# Altium schematic sheet FPGA_SUPPLY.SchDoc(FPGA_SUPPLY)
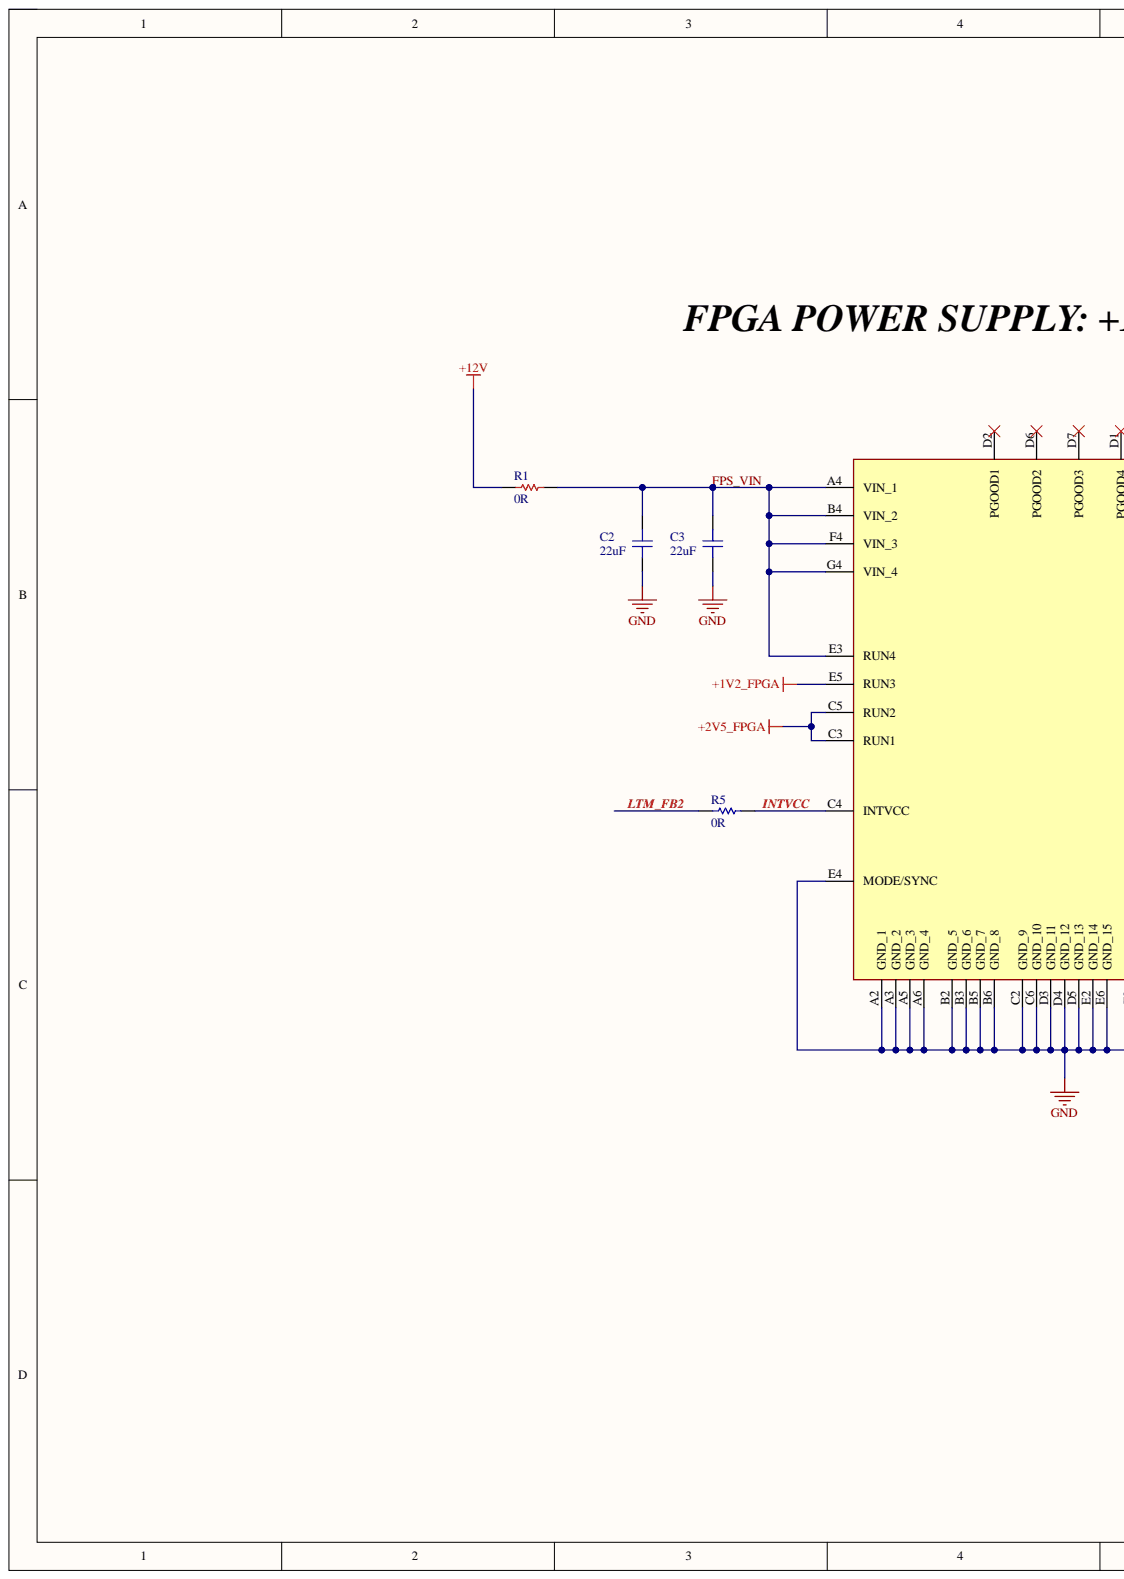
[diagram p0: FPGA_SUPPLY.SchDoc, left]
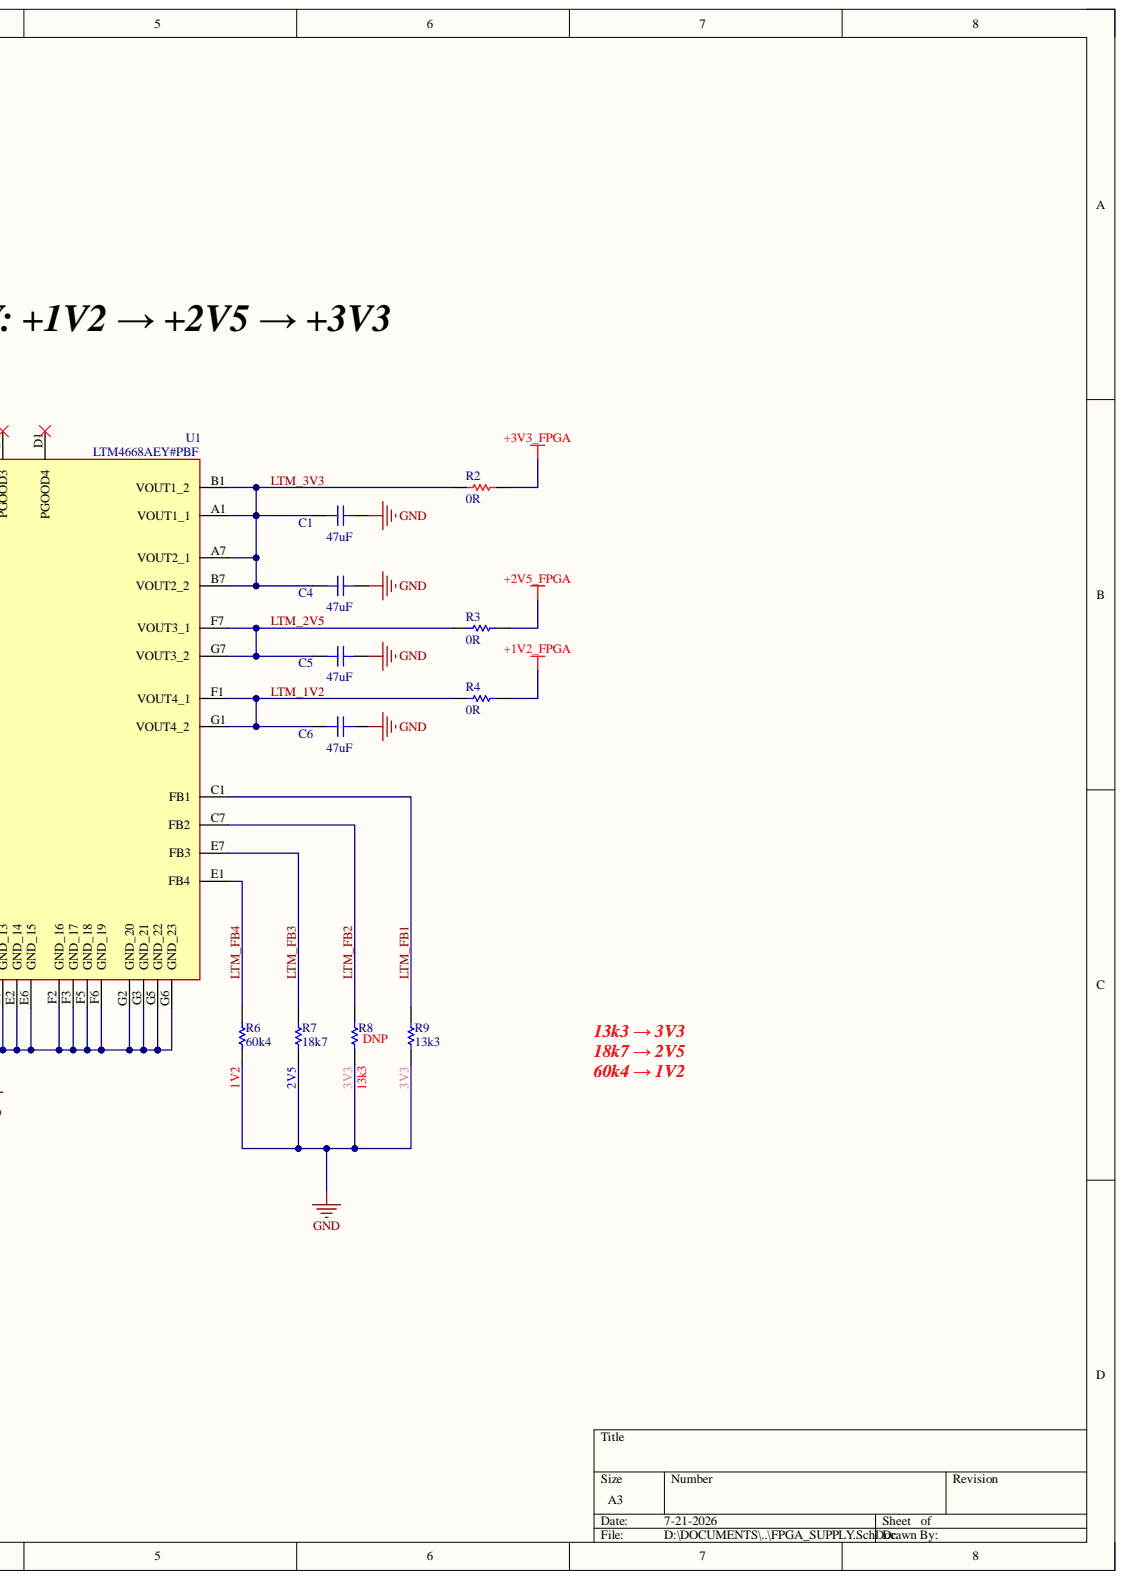
[diagram p1: FPGA_SUPPLY.SchDoc, right]

## Parts
C1  47uF  [CAP_1206]
C2  22uF  [CAP_1206]
C3  22uF  [CAP_1206]
C4  47uF  [CAP_1206]
C5  47uF  [CAP_1206]
C6  47uF  [CAP_1206]
R1  0R  [RES_1206]
R2  0R  [RES_1206]
R3  0R  [RES_0805]
R4  0R  [RES_0805]
R5  0R  [RES_0402]
R6  60k4  [RES_0402]
R7  18k7  [RES_0402]
R8  13k3  [RES_0402]
R9  13k3  [RES_0402]
U1  LTM4668AEY#PBF  [BGA49C80P7X7_625X625X230]

## Nets
+1V2_FPGA: R4.2 U1.E5(RUN3)
+2V5_FPGA: R3.2 U1.C3(RUN1) U1.C5(RUN2)
+3V3_FPGA: R2.2
+12V: R1.1
GND: C1.1 C2.1 C3.1 C4.1 C5.1 C6.1 R6.2 R7.2 R8.2 R9.2 U1.A2(GND_1) U1.A3(GND_2) U1.A5(GND_3) U1.A6(GND_4) U1.B2(GND_5) U1.B3(GND_6) U1.B5(GND_7) U1.B6(GND_8) U1.C2(GND_9) U1.C6(GND_10) U1.D3(GND_11) U1.D4(GND_12) U1.D5(GND_13) U1.E2(GND_14) U1.E4(MODE/SYNC) U1.E6(GND_15) U1.F2(GND_16) U1.F3(GND_17) U1.F5(GND_18) U1.F6(GND_19) U1.G2(GND_20) U1.G3(GND_21) U1.G5(GND_22) U1.G6(GND_23)
FPS_VIN: C2.2 C3.2 R1.2 U1.A4(VIN_1) U1.B4(VIN_2) U1.E3(RUN4) U1.F4(VIN_3) U1.G4(VIN_4)
INTVCC: R5.2 U1.C4(INTVCC)
LTM_1V2: C6.2 R4.1 U1.F1(VOUT4_1) U1.G1(VOUT4_2)
LTM_2V5: C5.2 R3.1 U1.F7(VOUT3_1) U1.G7(VOUT3_2)
LTM_3V3: C1.2 C4.2 R2.1 U1.A1(VOUT1_1) U1.A7(VOUT2_1) U1.B1(VOUT1_2) U1.B7(VOUT2_2)
LTM_FB1: R9.1 U1.C1(FB1)
LTM_FB2: R5.1 R8.1 U1.C7(FB2)
LTM_FB3: R7.1 U1.E7(FB3)
LTM_FB4: R6.1 U1.E1(FB4)

Not connected: U1 D1(PGOOD4) D2(PGOOD1) D6(PGOOD2) D7(PGOOD3)

## Library data of the parts
C1-C6 = CAP_1206_25V: C1206_50V-GRM21BR61A226ME44L; Component Kind: Standard; Library Name: FPGA_LIB.SchLib
R1 R2 = RES_1206: R1206; Component Kind: Standard
  R1: Library Name: FPGA_LIB.SCHLIB
  R2: Library Name: FPGA_LIB.SchLib
R3 R4 = RES_0805: R0805; Component Kind: Standard; Library Name: FPGA_LIB.SchLib
R5-R7 R9 = RES_0402: R0402; Component Kind: Standard; Library Name: FPGA_LIB.SchLib
R8 = RES_0402: R0402, DNP; Component Kind: Standard; Library Name: FPGA_LIB.SchLib
U1 = LTM4668AEY#PBF: IC; Manufacturer_Name: Analog Devices; Arrow Part Number: LTM4668AEY#PBF; Arrow Price/Stock: https://www.arrow.com/en/products/ltm4668aeypbf/analog-devices?region=nac; Component Kind: Standard; Datasheet Link: https://www.analog.com/media/en/technical-documentation/data-sheets/LTM4668A.pdf; Height: 2.3mm; Library Name: FPGA_LIB.SchLib; Mouser Part Number: 584-LTM4668AEY#PBF; Mouser Price/Stock: https://www.mouser.co.uk/ProductDetail/Analog-Devices/LTM4668AEYPBF?qs=XeJtXLiO41QqlmbrcY%2F6Zw%3D%3D; MPN: LTM4668AEY#PBF
